# Altium schematic sheet Calc_Schem.SchDoc(Calc_Schem)
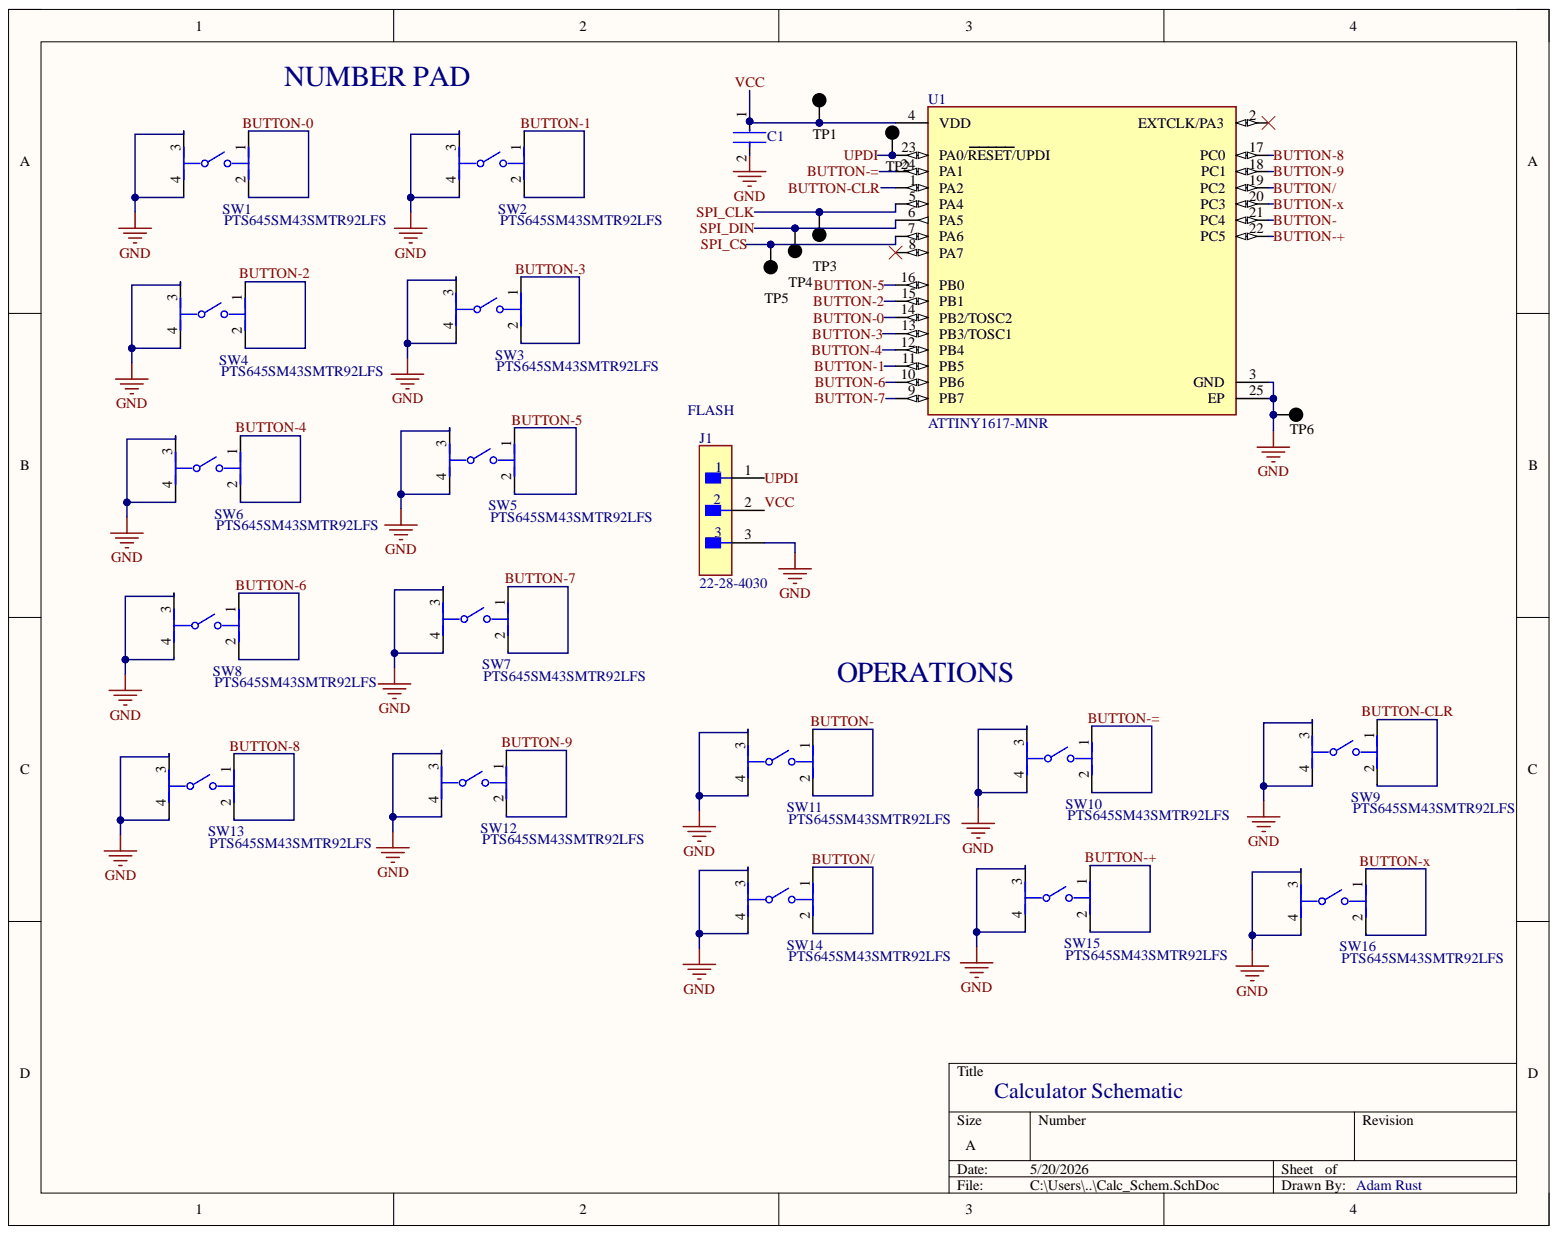
[diagram p0: Calc_Schem.SchDoc]

## Parts
C1  GRM155R71C104KA88D  [FP-GRM15-MFG]  (value not drawn)
J1  22-28-4030  [FP-22-28-4030-MFG]
SW1  PTS645SM43SMTR92LFS  [FP-PTS645SM43SMTR92LFS-MFG]
SW2  PTS645SM43SMTR92LFS  [FP-PTS645SM43SMTR92LFS-MFG]
SW3  PTS645SM43SMTR92LFS  [FP-PTS645SM43SMTR92LFS-MFG]
SW4  PTS645SM43SMTR92LFS  [FP-PTS645SM43SMTR92LFS-MFG]
SW5  PTS645SM43SMTR92LFS  [FP-PTS645SM43SMTR92LFS-MFG]
SW6  PTS645SM43SMTR92LFS  [FP-PTS645SM43SMTR92LFS-MFG]
SW7  PTS645SM43SMTR92LFS  [FP-PTS645SM43SMTR92LFS-MFG]
SW8  PTS645SM43SMTR92LFS  [FP-PTS645SM43SMTR92LFS-MFG]
SW9  PTS645SM43SMTR92LFS  [FP-PTS645SM43SMTR92LFS-MFG]
SW10  PTS645SM43SMTR92LFS  [FP-PTS645SM43SMTR92LFS-MFG]
SW11  PTS645SM43SMTR92LFS  [FP-PTS645SM43SMTR92LFS-MFG]
SW12  PTS645SM43SMTR92LFS  [FP-PTS645SM43SMTR92LFS-MFG]
SW13  PTS645SM43SMTR92LFS  [FP-PTS645SM43SMTR92LFS-MFG]
SW14  PTS645SM43SMTR92LFS  [FP-PTS645SM43SMTR92LFS-MFG]
SW15  PTS645SM43SMTR92LFS  [FP-PTS645SM43SMTR92LFS-MFG]
SW16  PTS645SM43SMTR92LFS  [FP-PTS645SM43SMTR92LFS-MFG]
TP1  BTN  [KSTN-5011_V]  (value not drawn)
TP2  BTN  [KSTN-5011_V]  (value not drawn)
TP3  BTN  [KSTN-5011_V]  (value not drawn)
TP4  BTN  [KSTN-5011_V]  (value not drawn)
TP5  BTN  [KSTN-5011_V]  (value not drawn)
TP6  BTN  [KSTN-5011_V]  (value not drawn)
U1  ATTINY1617-MNR  [FP-C04-21386-IPC_A]

## Nets
GND: C1.2 J1.3 SW1.3 SW1.4 SW2.3 SW2.4 SW3.3 SW3.4 SW4.3 SW4.4 SW5.3 SW5.4 SW6.3 SW6.4 SW7.3 SW7.4 SW8.3 SW8.4 SW9.3 SW9.4 SW10.3 SW10.4 SW11.3 SW11.4 SW12.3 SW12.4 SW13.3 SW13.4 SW14.3 SW14.4 SW15.3 SW15.4 SW16.3 SW16.4 TP6.1 U1.3(GND) U1.25(EP)
BUTTON-: SW11.1 SW11.2 U1.21(PC4)
BUTTON-0: SW1.1 SW1.2 U1.14(PB2/TOSC2)
BUTTON-1: SW2.1 SW2.2 U1.11(PB5)
BUTTON-2: SW4.1 SW4.2 U1.15(PB1)
BUTTON-3: SW3.1 SW3.2 U1.13(PB3/TOSC1)
BUTTON-4: SW6.1 SW6.2 U1.12(PB4)
BUTTON-5: SW5.1 SW5.2 U1.16(PB0)
BUTTON-6: SW8.1 SW8.2 U1.10(PB6)
BUTTON-7: SW7.1 SW7.2 U1.9(PB7)
BUTTON-8: SW13.1 SW13.2 U1.17(PC0)
BUTTON-9: SW12.1 SW12.2 U1.18(PC1)
BUTTON-+: SW15.1 SW15.2 U1.22(PC5)
BUTTON-=: SW10.1 SW10.2 U1.24(PA1)
BUTTON-CLR: SW9.1 SW9.2 U1.1(PA2)
BUTTON-x: SW16.1 SW16.2 U1.20(PC3)
BUTTON/: SW14.1 SW14.2 U1.19(PC2)
SPI_CLK: TP3.1 U1.5(PA4)
SPI_CS: TP5.1 U1.7(PA6)
SPI_DIN: TP4.1 U1.6(PA5)
UPDI: J1.1 TP2.1 U1.23(PA0/RESET/UPDI)
VCC: C1.1 J1.2 TP1.1 U1.4(VDD)

Not connected: U1 2(EXTCLK/PA3) 8(PA7)

## Library data of the parts
C1 = CMP-2100-03641-2: CAP CER 0.1UF 16V X7R 0402; Manufacturer: Murata; Capacitance: 0.10μF; Material: Ceramic; Standoff Height: 0mm; Tolerance: 10%; Value: 0.10μF; Voltage Rating: 16Vdc; Category: Cap; Component Kind: Standard; ComponentLink2Description: Datasheet; ComponentLink2URL: https://search.murata.co.jp/Ceramy/image/img/A01X/G101/ENG/GRM155R71H471KA01-01A.pdf; Device Class L1: Passive Components; Device Class L2: Capacitors; Device Class L3: Ceramic Capacitors; Digikey Description: CAP CER 0.1UF 16V X7R 0402; Height: 0.55mm; Ipc Land Pattern Name: CAPC10050X219; Lead Free: yes; Library Name: Altium Content Vault; Manufacturer Part Number: GRM155R71C104KA88D; Mouser Description: 0402 0.1 uF 16V ±10 % Tolerance X7R SMT Multilayer Ceramic Capacitor; Octopart: https://octopart.com/grm155r71c104ka88d-murata-196880; Package: GRM15; RoHS: TRUE; Temperature: -55°C to +125°C; Temperature Characteristic: X7R; Temperature Range High: +125°C; Temperature Range Low: -55°C
J1 = CMP-04776-000098-1: UPDI PROG; Manufacturer: Molex; Conn Gender: Male; Conn Orientation: Vertical; Current Rating: 4A; Number Of Contacts: 3; Pitch: 2.54mm; Voltage Rating: 500V; Automotive: No; Category: Conn; Component Kind: Standard; ComponentLink2Description: Datasheet; ComponentLink2URL: https://www.molex.com/webdocs/datasheets/pdf/en-us/0022284030_PCB_HEADERS.pdf; Contact Material: Gold,Nickel; Device Class L1: Connectors; Device Class L2: Headers and Wire Housings; Device Class L3: unset; Digikey Description: CONN HEADER VERT 3POS 2.54MM; Footprint Url: https://www.molex.com/pdm_docs/sd/022284030_sd.pdf; Height: 8.88mm; Lead Free: Yes; Library Name: Altium Content Vault; Manufacturer Part Number: 22-28-4030; Mouser Description: Headers & Wire Housings 3P VERT HEADER Sn; Number Of Rows: 1; Octopart: https://octopart.com/0022284030-molex-10476094; Package: 22-28-4030; RoHS: TRUE; Temperature: -40°C to +80°C; Temperature Range High: +80°C; Temperature Range Low: -40°C
SW1-SW16 = CMP-2000-05160-2: SWITCH TACTILE SPST-NO 0.05A 12V; Actuator Length: 0.85mm; Actuator Material: Nylon; Actuator Type: Standard; Contact Resistance: 100mR; Current Rating: 50mA; Illumination Type: Non-Illuminated; Mechanical Life: 100000Cycles; Operating Force: 160gf; Switch Function: Off-Mom; Throw Configuration: SPST; Case/Package: SMD/SMT; Color: Black; Component Kind: Standard; Contact Material: Silver; Contact Plating: Silver; Depth: 9mm; Lead Free: Lead Free; Length: 6mm; Library Name: Altium Content Vault; Max Current Rating: 50mA; Max Operating Temperature: 60°C; Max Voltage Rating (DC): 12V; Min Operating Temperature: -20°C; Mount: Surface Mount; Orientation: Straight; Package Quantity: 1; Packaging: Tape and Reel; REACH SVHC: No SVHC; Termination: Gull Wing; Voltage Rating (DC): 12V
TP1-TP6 = CMP-1672-00003-4: Test Point, Black, Through Hole, RoHS, Bulk; Manufacturer: Keystone; Colour: Black; Component Kind: Standard; ComponentLink1Description: Manufacturer URL; ComponentLink1URL: http://www.keyelco.com/; ComponentLink2Description: Datasheet; ComponentLink2URL: http://www.keyelco.com/product-pdf.cfm?p=1320; Contact Material: Bronze; Contact Plating: Silver; DatasheetVersion: Rev. F, 12/2013; Height: 8.12 mm; Library Name: Altium Content Vault; Mount: Through Hole; Mounting Technology: Through Hole; Package Quantity: 100; PackageDescription: 1-Pin Through Hole Device, Dia 3.17 mm; PackageReference: 5011; Packaging: Bulk; Pins: 1; REACH SVHC: No SVHC; RoHSCompliant: true
U1 = CMP-06276-000207-1: IC MCU 8BIT 16KB FLASH 24VQFN; Core Architecture: AVR; Frequency: 20MHz; Interface: I2C; Number of I/Os: 22; Oscillator Type: Internal; Peripherals: Brown-out Detect/Reset; Case/Package: VQFN; Component Kind: Standard; Library Name: Altium Content Vault; Max Operating Temperature: 105°C; Memory Size: 16KB; Memory Type: FLASH; Min Operating Temperature: -40°C; Packaging: Tape and Reel; RoHS Compliant: Yes
